# Altium schematic sheet sensor.SchDoc
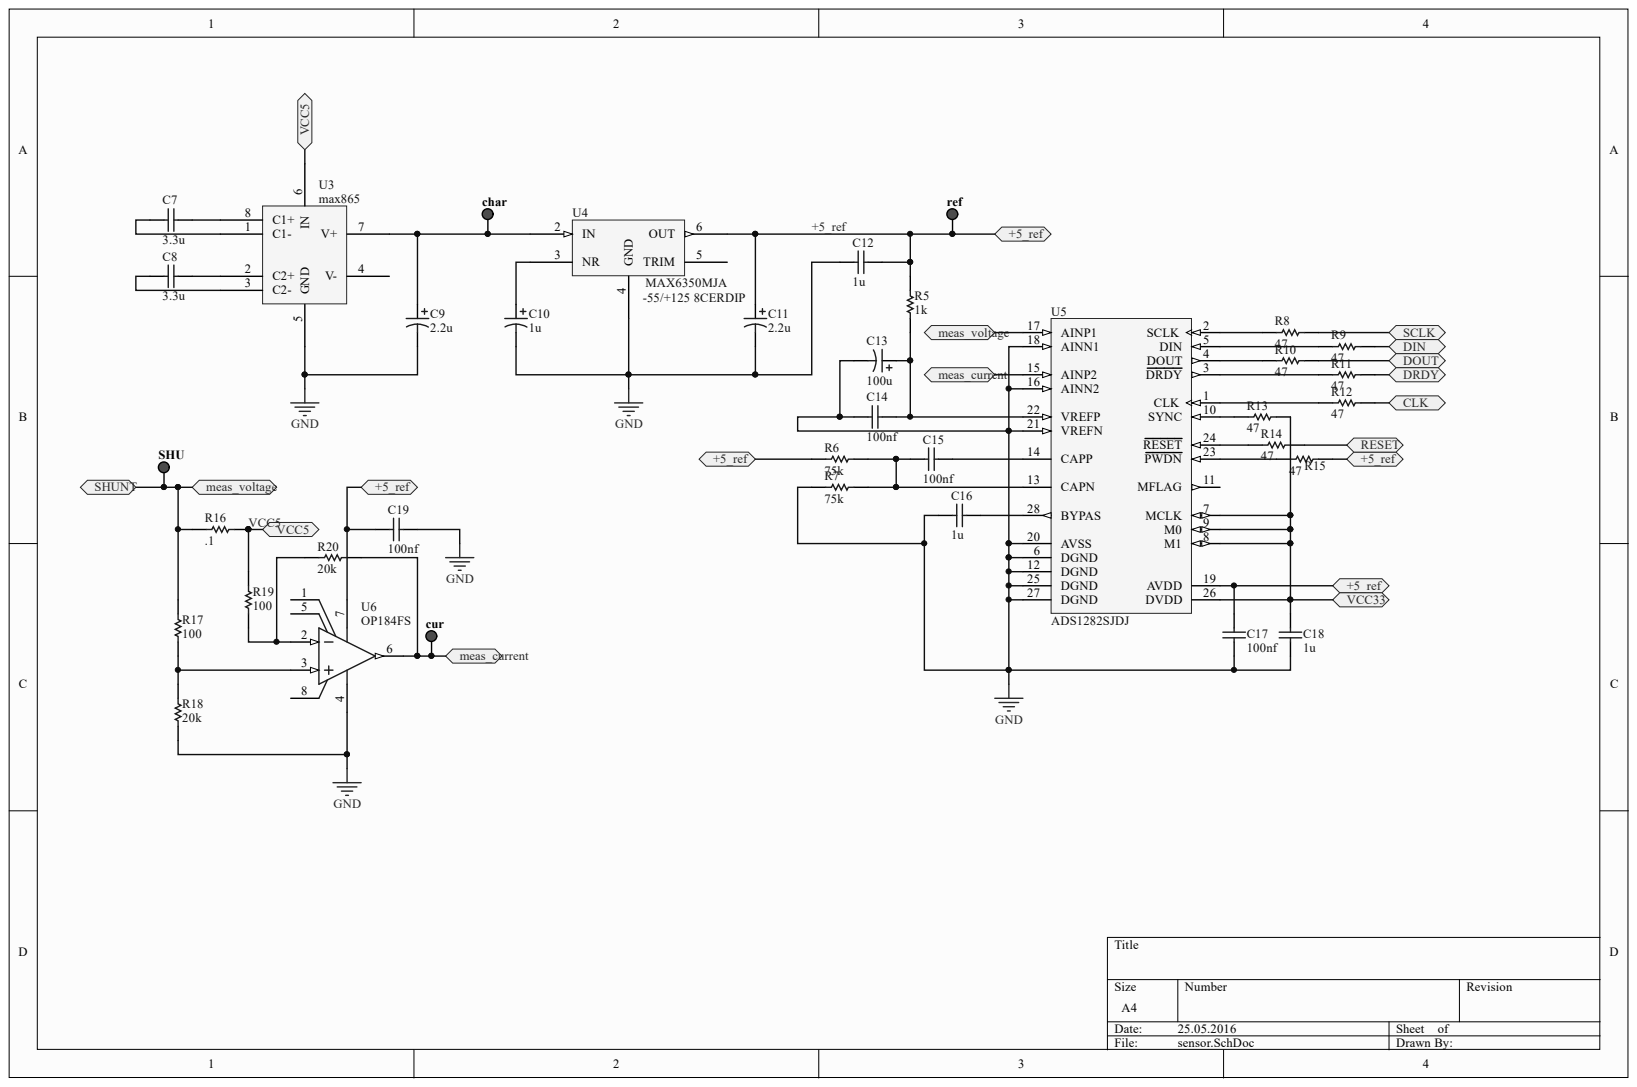
[diagram p0: sensor.SchDoc]

## Parts
C7  3.3u  [CAPC2013X145X50LL20T25]
C8  3.3u  [CAPC2013X145X50LL20T25]
C9  2.2u  [AVX-B]
C10  1u  [AVX-A]
C11  2.2u  [AVX-B]
C12  1u  [CAPC2013X145X50LL20T25]
C13  100u  [AVX-B]
C14  100nf  [CAPC1608X87X35LL15T15]
C15  100nf  [CAPC1608X87X35LL15T15]
C16  1u  [CAPC2013X145X50LL20T25]
C17  100nf  [CAPC1608X87X35LL15T15]
C18  1u  [CAPC2013X145X50LL20T25]
C19  100nf  [CAPC1608X87X35LL15T15]
char  (no value)  [PCB-gm3691gcwmiln8nb0eoq-1]  (no BOM)
cur  (no value)  [PCB-gm3691gcwmiln8nb0eoq-1]  (no BOM)
R5  1k  [PCB-4097432-1]
R6  75k  [PCB-4097432-1]
R7  75k  [PCB-4097432-1]
R8  47  [PCB-4097432-1]
R9  47  [PCB-4097432-1]
R10  47  [PCB-4097432-1]
R11  47  [PCB-4097432-1]
R12  47  [PCB-4097432-1]
R13  47  [PCB-4097432-1]
R14  47  [PCB-4097432-1]
R15  47  [PCB-4097432-1]
R16  .1  [PCB-4097432-1]
R17  100  [PCB-4097432-1]
R18  20k  [PCB-4097432-1]
R19  100  [PCB-4097432-1]
R20  20k  [PCB-4097432-1]
ref  (no value)  [PCB-gm3691gcwmiln8nb0eoq-1]  (no BOM)
SHU  (no value)  [PCB-gm3691gcwmiln8nb0eoq-1]  (no BOM)
U3  max865  [PCB-ewhyswb3qaia6rbo6ras-1]
U4  MAX6350MJA  [PCB-1470503-1]
U5  ADS1282SJDJ  [TI-JDJ28]
U6  OP184FS  [ADI-R-8_M]

## Ports
+5_REF, CLK, DIN, DOUT, DRDY, MEAS_CURRENT, MEAS_VOLTAGE, RESET, SCLK, SHUNT, VCC5, VCC33

## Nets
GND: C9.2 C10.2 C11.2 C12.2 C13.2 C14.2 C16.1 C17.1 C18.2 C19.2 R7.2 R18.1 U3.5(GND) U4.4(GND) U5.6(DGND) U5.12(DGND) U5.16(AINN2) U5.18(AINN1) U5.20(AVSS) U5.21(VREFN) U5.25(DGND) U5.27(DGND) U6.4
+5_REF: C11.1 C12.1 C17.2 C19.1 R5.1 R6.2 R15.2 ref.TP U4.6(OUT) U5.19(AVDD) U6.7 ; port +5_REF
CLK: R12.2 ; port CLK
DIN: R9.2 ; port DIN
DOUT: R10.2 ; port DOUT
DRDY: R11.2 ; port DRDY
RESET: R14.2 ; port RESET
SCLK: R8.2 ; port SCLK
SHUNT: R16.2 R17.2 SHU.TP U5.17(AINP1) ; port SHUNT
VCC5: R16.1 R19.2 U3.6(IN) ; port VCC5
VCC33: C18.1 R13.2 U5.7(MCLK) U5.8(M1) U5.9(M0) U5.26(DVDD) ; port VCC33
NetC7_1: C7.1 U3.8(C1+)
NetC7_2: C7.2 U3.1(C1-)
NetC8_1: C8.1 U3.2(C2+)
NetC8_2: C8.2 U3.3(C2-)
NetC9_1: C9.1 char.TP U3.7(V+) U4.2(IN)
NetC10_1: C10.1 U4.3(NR)
NetC13_1: C13.1 C14.1 R5.2 U5.22(VREFP)
NetC15_1: C15.1 U5.14(CAPP)
NetC15_2: C15.2 R6.1 R7.1 U5.13(CAPN)
NetC16_2: C16.2 U5.28(BYPAS)
Netcur_TP: cur.TP R20.1 U5.15(AINP2) U6.6 ; port MEAS_CURRENT
NetR8_1: R8.1 U5.2(SCLK)
NetR9_1: R9.1 U5.5(DIN)
NetR10_1: R10.1 U5.4(DOUT)
NetR11_1: R11.1 U5.3(DRDY)
NetR12_1: R12.1 U5.1(CLK)
NetR13_1: R13.1 U5.10(SYNC)
NetR14_1: R14.1 U5.24(RESET)
NetR15_1: R15.1 U5.23(PWDN)
NetR17_1: R17.1 R18.2 U6.3
NetR19_1: R19.1 R20.2 U6.2

Not connected: U3 4(V-); U4 5(TRIM); U5 11(MFLAG); U6 1 5 8

## Library data of the parts
C7 C8 C12 C16 C18 = Kemet C0805C104J5RAC7800-2: 0805 X7R ceramic capacitor, 50V 0.1uF; Capacitance: 1e-07 F; Capacitance Tolerance: ±5%; Lead-Free Status: R; Voltage Rating (DC): 50.0 V; Case/Package: 0805; Component Kind: Standard; Dielectric Characteristic: X7R; Dielectric Material: Ceramic; Library Name: FavoritesLibrary; Mounting Style: Surface Mount; OctopartID: 9cb2558377904375; Octopart Url: https://octopart.com/c0805c104j5rac7800-kemet-3919428; RoHS: Compliant
C9 C11 C13 = AVX TAJB106K025RNJ-1: Cap Tant Solid 10uF 25V B CASE 10% (3.5 X 2.8 X 1.9mm) SMD 3528-21 2.5 Ohm 125?C T/R; Capacitance: 1e-05 F; Equivalent Series Resistance (ESR): 2.5 ?; Lead-Free Status: Lead Free; Voltage Rating (DC): 25.0 V; Capacitance Tolerance: ±10%; Case/Package: 1210; Component Kind: Standard; Library Name: FavoritesLibrary; Manufacturer Part Number: TAJB106K025RNJ; Mounting Style: Surface Mount; OctopartID: 6223aa0b3946bde4; Octopart Url: http://octopart.com/tajb106k025rnj-avx-19037699; Packaging: Cut Tape (CT); REACH SVHC Compliance: No SVHC; RoHS: Compliant; Seller Landing  Url: https://octopart-clicks.com/click/track?country=US&ak=8157f166&sig=07e128a&sid=2402&ppid=19037699&vpid=84862021&ct=offers
C10 = AVX TAJA225K016RNJ-3: Cap Tant Solid 2.2uF 16V A CASE 10% (3.2 X 1.6 X 1.6mm) SMD 3216-18 6.5 Ohm 125?C T/R; Capacitance: 2.2e-06 F; Dielectric Material: Tantalum; Equivalent Series Resistance (ESR): 6.5 ?; Lead-Free Status: Lead Free; Q-Factor: 6.0; Voltage Rating (DC): 16.0 V; Capacitance Tolerance: ±10%; Case/Package: 1206; Component Kind: Standard; Library Name: FavoritesLibrary; Manufacturer Part Number: TAJA225K016RNJ; Mounting Style: Surface Mount; Number of Pins: 2; OctopartID: fda334ba4c102264; Octopart Url: http://octopart.com/taja225k016rnj-avx-1283285; REACH SVHC Compliance: No SVHC; RoHS: Compliant; Seller Landing  Url: https://octopart-clicks.com/click/track?country=US&ak=8157f166&sig=0f15cbe&sid=5489&ppid=1283285&vpid=17557015&ct=offers
C14 C15 C17 C19 = Kemet C0603C104K5RAC7867-2: Multilayer Ceramic Capacitors MLCC - SMD/SMT 50volts 0.1uF X7R 10%; Capacitance: 1e-07 F; Lead-Free Status: R; Voltage Rating (DC): 50.0 V; Capacitance Tolerance: ±10%; Case/Package: 0603; Component Kind: Standard; Dielectric Characteristic: X7R; Dielectric Material: Ceramic; Library Name: FavoritesLibrary; Mounting Style: Surface Mount; OctopartID: 99d350e26f664614; Octopart Url: https://octopart.com/c0603c104k5rac7867-kemet-3920851; RoHS: Compliant
char cur ref SHU = Keystone Electronics 5000-14: KEYSTONE   5000   TEST POINT, PCB, THROUGH HOLE; Manufacturer Part Number: 5000; Lead-Free Status: Lead Free; Color: Red; Contact Material: Phosphor Bronze; Library Name: FavoritesLibrary; Manufacturer: Keystone; OctopartID: c16f0865c3341ea8; Octopart Url: https://octopart.com/5000-keystone-10045; Packaging: Bag; REACH SVHC Compliance: No SVHC; RoHS: Compliant; Size-Diameter: 2.54 mm; Size-Height: 7.62 mm; Size-Inner Diameter: 1.27 mm; Size-Length: 7.62 mm
R5-R20 = Bourns CR0805-FX-4701GLF-1: Res Thick Film 0805 4.7K Ohm 1% 1/8W ±100ppm/°C Molded SMD SMD Paper Tape on Plastic Reel; Power Rating: 125 mW; Resistance: 4.70 k?; Voltage Rating (DC): 150 V; Case/Package: 0805; Component Kind: Standard; Library Name: FavoritesLibrary; Manufacturer Part Number: CR0805-FX-4701GLF; Mounting Style: Surface Mount; Number of Pins: 2; OctopartID: 97505e06006c4897; Octopart URL: http://octopart.com/cr0805-fx-4701glf-bourns-6074970; Packaging: Tape & Reel (TR), Cut Tape (CT); REACH SVHC Compliance: No SVHC; Resistance Tolerance: ±1%; RoHS: Compliant; Size-Length: 2.01 mm; Size-Thickness: 508 µm; Size-Width: 1.24 mm
U3 = CMP-ec76awf2qy4sz8vne3gm-2: Dual-Output Charge Pump; Component Kind: Standard; CustomComponentID: ec76awf2qy4sz8vne3gm; Library Name: CommunityVault
U4 = CMP-1470503-4: IC VREF SERIES 4.096V 8DIP; Component Kind: Standard; Library Name: CommunityVault; Lifecycle Status: Not Listed by Manufacturer; OctopartID: 2f1ae48ed561f0dd; Octopart Url: https://octopart.com/max6341cpa%2B-maxim+integrated-43966237
U5 = CMP-1063059-2: High Temperature Ultra High Resolution Delta Sigma ADC with PGA for Seismic and Energy Exploration, -55 to 210 degC, 28-pin DIP (JDJ28); Lead-Free Status: Contains Lead; Power Consumption: 0.03 W; Component Kind: Standard; Library Name: FavoritesLibrary; Lifecycle Status: Active; OctopartID: 054bcd5fcf8fda8c; Octopart Url: https://octopart.com/ads1282sjdj-texas+instruments-18804642; RoHS: Non-Compliant
U6 = OP184FS-3: Single Channel Wide Bandwidth Operational Amplifier, 4.25 MHz BW, 4 V/us SR, Industrial, 8-pin SOIC (R-8), Tube; Common Mode Rejection Ratio: 90.0 dB; Gain Bandwidth Product: 4250000.0 Hz; Input Bias Current: 6e-08 A; Input Impedance: 50000000.0 ?; Lead-Free Status: Contains Lead; Mil-Spec: No; Number of Channels: 1; Slew Rate: 2.4 V/?s; Supply Current: 0.002 A; Case/Package: SOIC; Component Kind: Standard; Library Name: FavoritesLibrary; Lifecycle Status: Pending Obsolescence; Mounting Style: Surface Mount; Number of Circuits: 1; Number of Pins: 8; OctopartID: 56569f5e64468991; Octopart Url: https://octopart.com/op184fs-analog+devices-137078; Packaging: Tube; RoHS: Non-Compliant
